# Altium schematic sheet STM32WB55 Sensor MCU.SchDoc("U_STM32WB55 Sensor MCU")
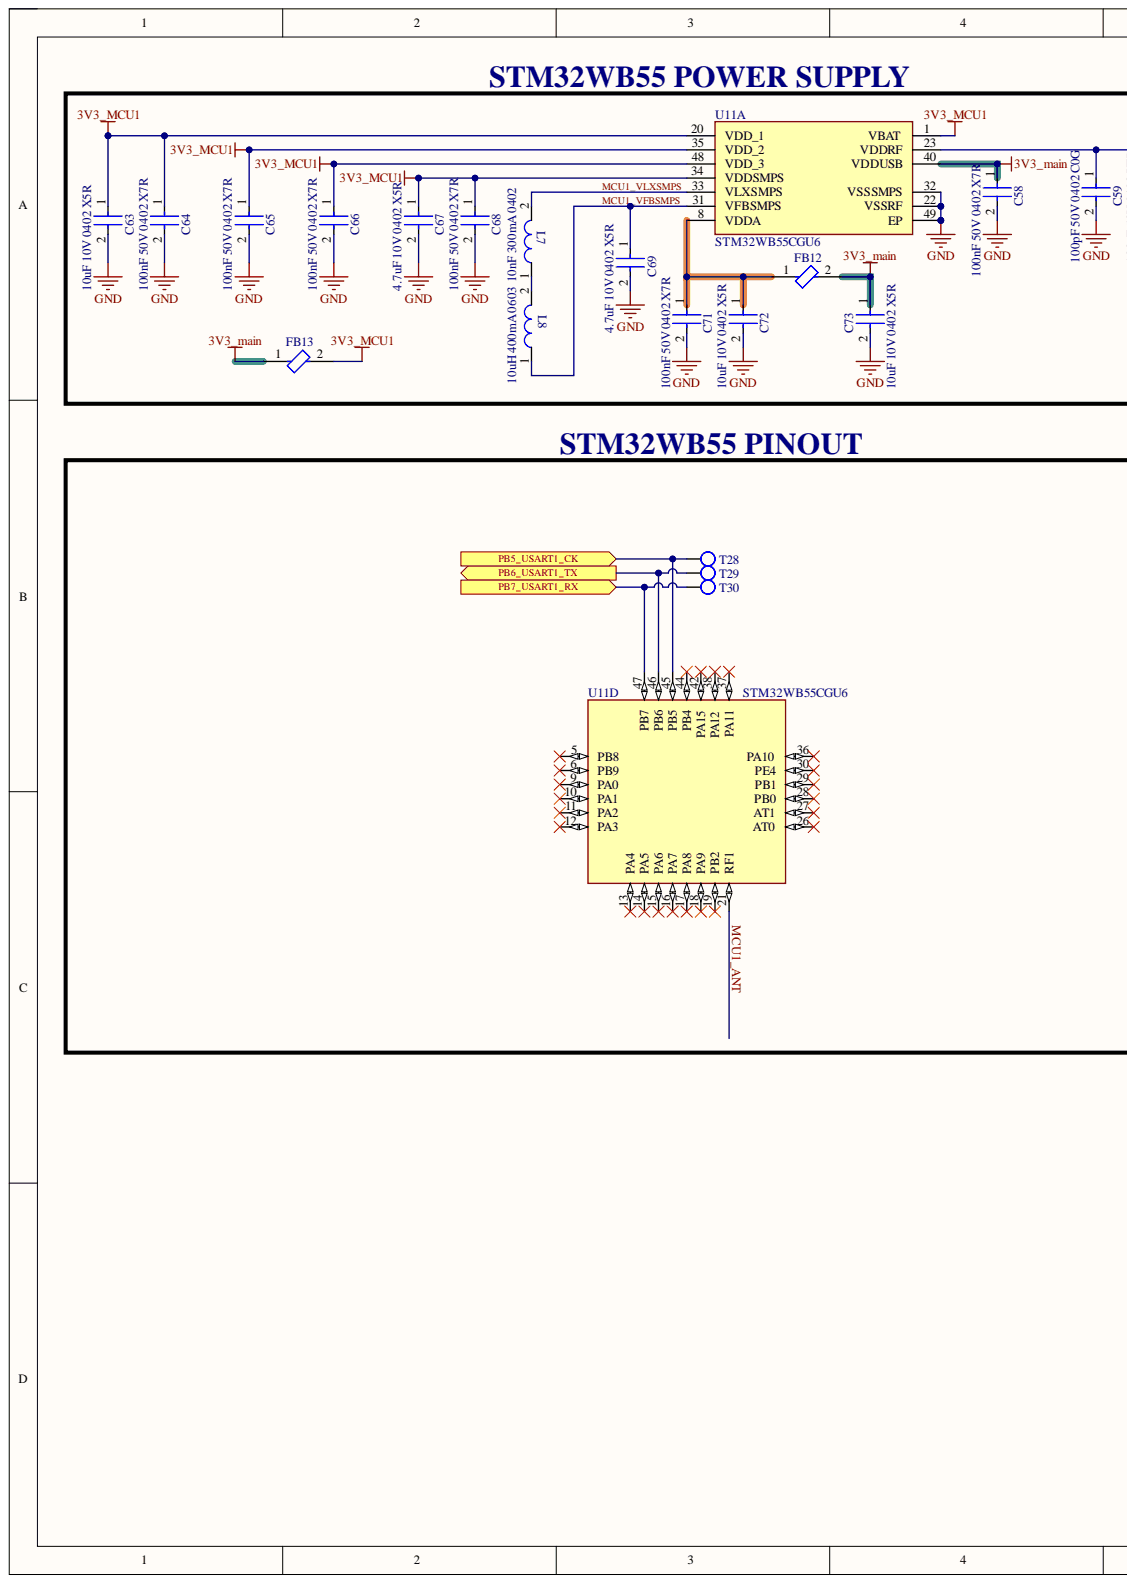
[diagram p0: STM32WB55 Sensor MCU.SchDoc, left]
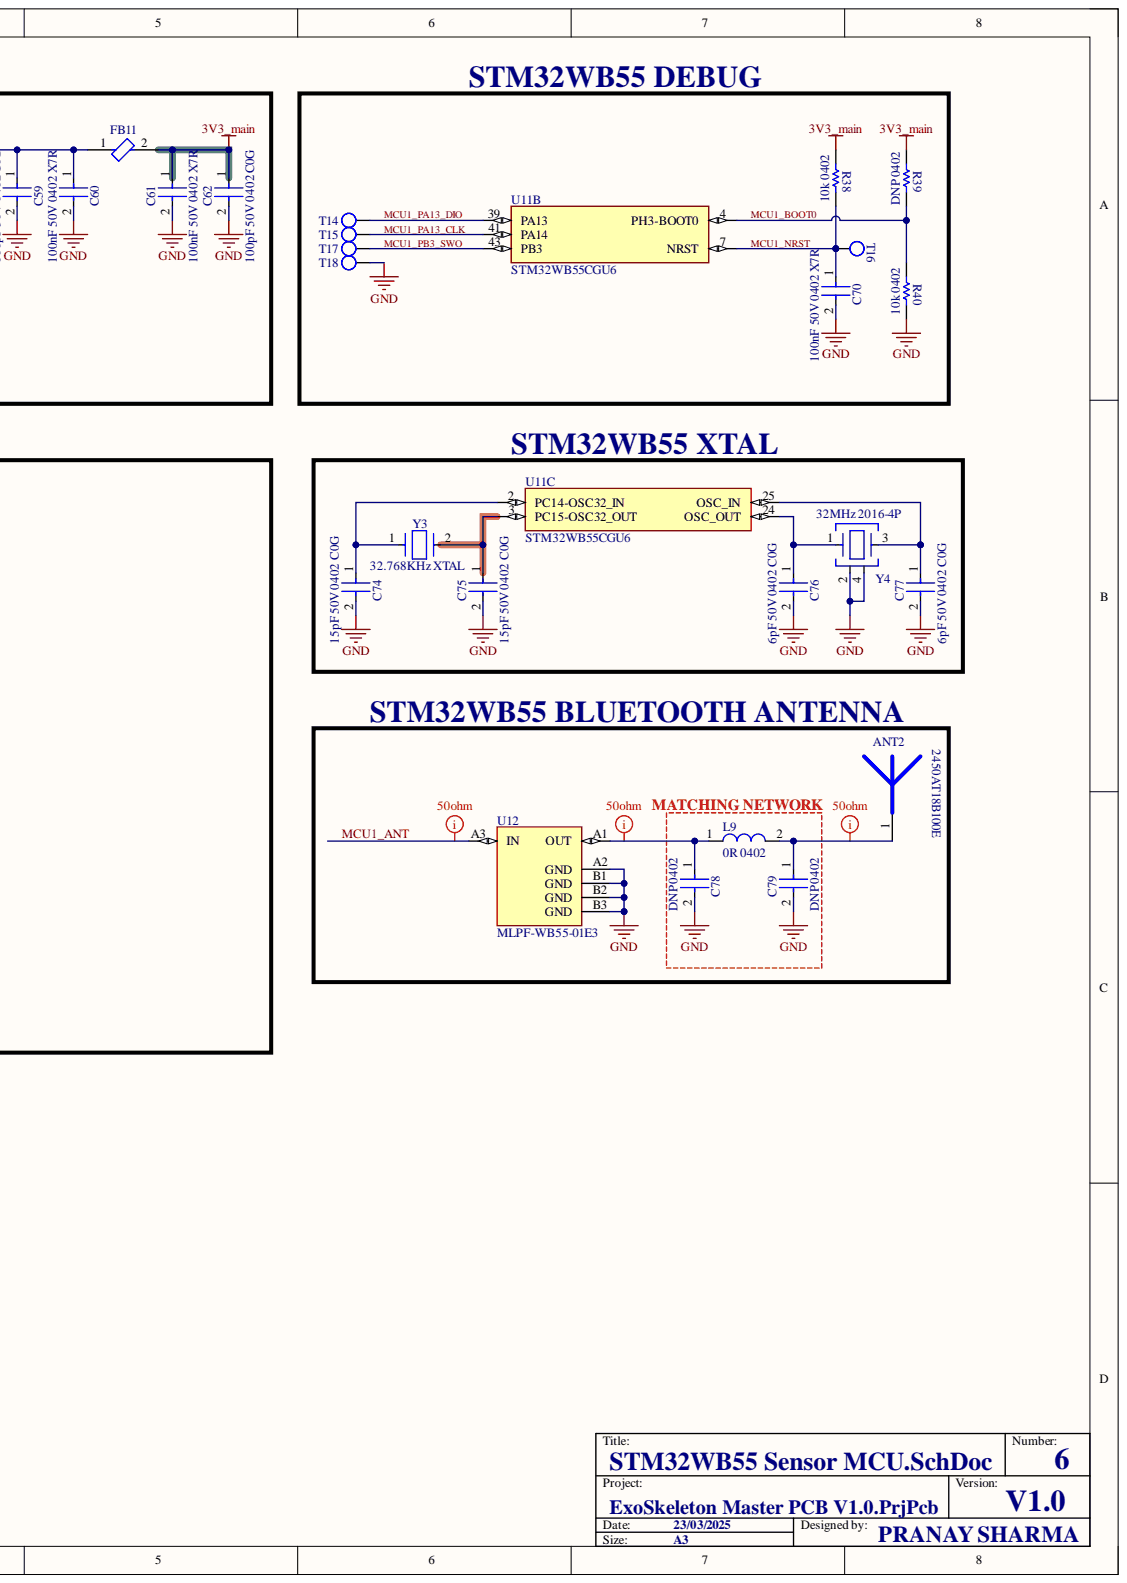
[diagram p1: STM32WB55 Sensor MCU.SchDoc, right]

## Parts
ANT2  2450AT18B100E  [2450AT18B100E IPC-C]
C58  100nF 50V 0402 X7R  [0402 IPC CLASS C CAPACITOR]
C59  100pF 50V 0402 C0G  [0402 IPC CLASS C CAPACITOR]
C60  100nF 50V 0402 X7R  [0402 IPC CLASS C CAPACITOR]
C61  100nF 50V 0402 X7R  [0402 IPC CLASS C CAPACITOR]
C62  100pF 50V 0402 C0G  [0402 IPC CLASS C CAPACITOR]
C63  10uF 10V 0402 X5R  [0402 IPC CLASS C CAPACITOR]
C64  100nF 50V 0402 X7R  [0402 IPC CLASS C CAPACITOR]
C65  100nF 50V 0402 X7R  [0402 IPC CLASS C CAPACITOR]
C66  100nF 50V 0402 X7R  [0402 IPC CLASS C CAPACITOR]
C67  4.7uF 10V 0402 X5R  [0402 IPC CLASS C CAPACITOR]
C68  100nF 50V 0402 X7R  [0402 IPC CLASS C CAPACITOR]
C69  4.7uF 10V 0402 X5R  [0402 IPC CLASS C CAPACITOR]
C70  100nF 50V 0402 X7R  [0402 IPC CLASS C CAPACITOR]
C71  100nF 50V 0402 X7R  [0402 IPC CLASS C CAPACITOR]
C72  10uF 10V 0402 X5R  [0402 IPC CLASS C CAPACITOR]
C73  10uF 10V 0402 X5R  [0402 IPC CLASS C CAPACITOR]
C74  15pF 50V 0402 C0G  [0402 IPC CLASS C CAPACITOR]
C75  15pF 50V 0402 C0G  [0402 IPC CLASS C CAPACITOR]
C76  6pF 50V 0402 C0G  [0402 IPC CLASS C CAPACITOR]
C77  6pF 50V 0402 C0G  [0402 IPC CLASS C CAPACITOR]
C78  DNP 0402  [0402 IPC CLASS C CAPACITOR]
C79  DNP 0402  [0402 IPC CLASS C CAPACITOR]
FB11  BLM15AX102SN1D  [0402 IPC CLASS C FERRITE BEAD]  (value not drawn)
FB12  BLM15AX102SN1D  [0402 IPC CLASS C FERRITE BEAD]  (value not drawn)
FB13  BLM15AX102SN1D  [0402 IPC CLASS C FERRITE BEAD]  (value not drawn)
L7  10nF 300mA 0402  [0402 IPC CLASS C FERRITE BEAD]
L8  10uH 400mA 0603  [0603 IPC CLASS C INDUCTOR]
L9  0R 0402  [0402 IPC CLASS C FERRITE BEAD]
R38  10k 0402  [0402 IPC CLASS C RESISTOR]
R39  DNP 0402  [0402 IPC CLASS C RESISTOR]  (no BOM)
R40  10k 0402  [0402 IPC CLASS C RESISTOR]
T14  Test Point TH  [Test Point TH]  (value not drawn)  (no BOM)
T15  Test Point TH  [Test Point TH]  (value not drawn)  (no BOM)
T16  Test Point TH  [Test Point TH]  (value not drawn)  (no BOM)
T17  Test Point TH  [Test Point TH]  (value not drawn)  (no BOM)
T18  Test Point TH  [Test Point TH]  (value not drawn)  (no BOM)
T28  TP  [Testpoint1]  (value not drawn)  (no BOM)
T29  TP  [Testpoint1]  (value not drawn)  (no BOM)
T30  TP  [Testpoint1]  (value not drawn)  (no BOM)
U11  STM32WB55CGU6  [UFQFPN48 IPC-C]  (parts A,B,C,D)
U12  MLPF-WB55-01E3  [MLPF-WB55-01E3]
Y3  32.768KHz XTAL  [SMD3215-2P IPC-C]
Y4  32MHz 2016-4P  [SMD2016-4P IPC-C]

## Ports
PB5_USART1_CK, PB6_USART1_TX, PB7_USART1_RX

## Nets
3V3_main: C58.1 C61.1 C62.1 C73.1 FB11.2 FB12.2 FB13.1 R38.2 R39.2 U11.40(VDDUSB)
3V3_MCU1: C63.1 C64.1 C65.1 C66.1 C67.1 C68.1 FB13.2 U11.1(VBAT) U11.20(VDD_1) U11.34(VDDSMPS) U11.35(VDD_2) U11.48(VDD_3)
GND: C58.2 C59.2 C60.2 C61.2 C62.2 C63.2 C64.2 C65.2 C66.2 C67.2 C68.2 C69.2 C70.2 C71.2 C72.2 C73.2 C74.2 C75.2 C76.2 C77.2 C78.2 C79.2 R40.1 T18.0 U11.22(VSSRF) U11.32(VSSSMPS) U11.49(EP) U12.A2(GND) U12.B1(GND) U12.B2(GND) U12.B3(GND) Y4.2 Y4.4
MCU1_ANT: U11.21(RF1) U12.A3(IN)
MCU1_BOOT0: R39.1 R40.2 U11.4(PH3-BOOT0)
MCU1_NRST: C70.1 R38.1 T16.0 U11.7(NRST)
MCU1_PA13_CLK: T15.0 U11.41(PA14)
MCU1_PA13_DIO: T14.0 U11.39(PA13)
MCU1_PB3_SWO: T17.0 U11.43(PB3)
MCU1_VFBSMPS: C69.1 L8.1 U11.31(VFBSMPS)
MCU1_VLXSMPS: L7.2 U11.33(VLXSMPS)
PB5_USART1_CK: T28.0 U11.45(PB5) ; port PB5_USART1_CK
PB6_USART1_TX: T29.0 U11.46(PB6) ; port PB6_USART1_TX
PB7_USART1_RX: T30.0 U11.47(PB7) ; port PB7_USART1_RX
NetANT2_1: ANT2.1 C79.1 L9.2
NetC59_1: C59.1 C60.1 FB11.1 U11.23(VDDRF)
NetC71_1: C71.1 C72.1 FB12.1 U11.8(VDDA)
NetC74_1: C74.1 U11.2(PC14-OSC32_IN) Y3.1
NetC75_1: C75.1 U11.3(PC15-OSC32_OUT) Y3.2
NetC76_1: C76.1 U11.24(OSC_OUT) Y4.1
NetC77_1: C77.1 U11.25(OSC_IN) Y4.3
NetC78_1: C78.1 L9.1 U12.A1(OUT)
NetL7_1: L7.1 L8.2
NetU11_5: U11.5(PB8)
NetU11_6: U11.6(PB9)
NetU11_9: U11.9(PA0)
NetU11_10: U11.10(PA1)
NetU11_11: U11.11(PA2)
NetU11_12: U11.12(PA3)
NetU11_13: U11.13(PA4)
NetU11_14: U11.14(PA5)
NetU11_15: U11.15(PA6)
NetU11_16: U11.16(PA7)
NetU11_17: U11.17(PA8)
NetU11_18: U11.18(PA9)
NetU11_19: U11.19(PB2)
NetU11_26: U11.26(AT0)
NetU11_27: U11.27(AT1)
NetU11_28: U11.28(PB0)
NetU11_29: U11.29(PB1)
NetU11_30: U11.30(PE4)
NetU11_36: U11.36(PA10)
NetU11_37: U11.37(PA11)
NetU11_38: U11.38(PA12)
NetU11_42: U11.42(PA15)
NetU11_44: U11.44(PB4)

## Library data of the parts
C78 C79 = 100nF 50V 0402 X7R: Component Kind: Standard; Library Name: Capacitor_Lib.SchLib
L7 L9 = SDCL1005C10NJTDF: Component Kind: Standard; Library Name: Inductor_Lib.SchLib
L8 = MGFL1608F100MT-LF: Component Kind: Standard; Library Name: Inductor_Lib.SchLib
R38 R40 = 10k 1% 0402: Component Kind: Standard; Library Name: Resistor_Lib.SchLib
R39 = 0R 1% 0402: Library Name: Resistor_Lib.SchLib
T28-T30 = Testpoint1: Library Name: Miscellaneous_Lib.SchLib
Y3 = H31003271C2070CY: Component Kind: Standard; Library Name: Crystals_and_Oscillators_Lib.SchLib
ANT2 = 2450AT18B100E: Component Kind: Standard; Library Name: Miscellaneous_Lib.SchLib
C58 C60 C61 C64-C66 C68 C70 C71 = 100nF 50V 0402 X7R: Component Kind: Standard; Library Name: Capacitor_Lib.SchLib
C59 C62 = 100pF 50V 0402 C0G: Component Kind: Standard; Library Name: Capacitor_Lib.SchLib
C63 C72 C73 = 10uF 10V 0402 X5R: Component Kind: Standard; Library Name: Capacitor_Lib.SchLib
C67 C69 = 4.7uF 10V 0402 X5R: Component Kind: Standard; Library Name: Capacitor_Lib.SchLib
C74 C75 = 15pF 50V 0402 C0G: Component Kind: Standard; Library Name: Capacitor_Lib.SchLib
C76 C77 = 6pF 50V 0402 C0G: Component Kind: Standard; Library Name: Capacitor_Lib.SchLib
FB11-FB13 = BLM15AX102SN1D: Component Kind: Standard; Library Name: Ferrite_Bead_Lib.SchLib
T14-T18 = Test Point TH: Library Name: Miscellaneous_Lib.SchLib
U11 = STM32WB55CGU6: Component Kind: Standard; Library Name: MCU_MPU_Lib.SchLib
U12 = MLPF-WB55-01E3: Component Kind: Standard; Library Name: Miscellaneous_Lib.SchLib
Y4 = 32MHz 2016-4P: Component Kind: Standard; Library Name: Crystals_and_Oscillators_Lib.SchLib
